# Altium schematic sheet 05-AT86RF215IQ-FEM.SchDoc
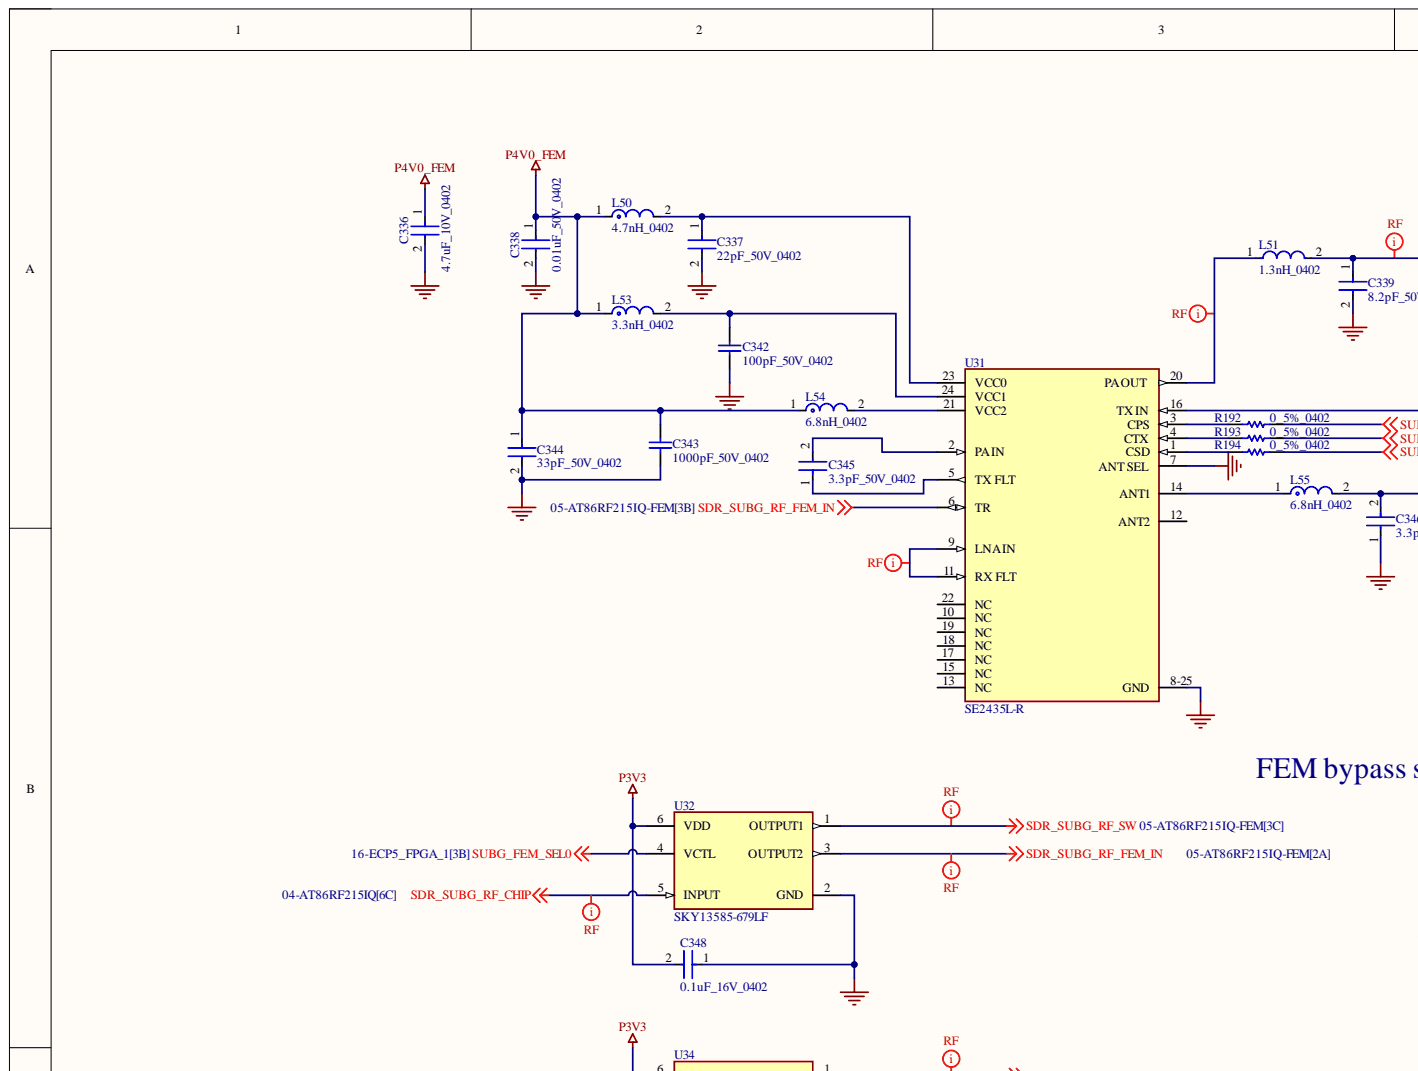
[diagram p0: 05-AT86RF215IQ-FEM.SchDoc, top left]
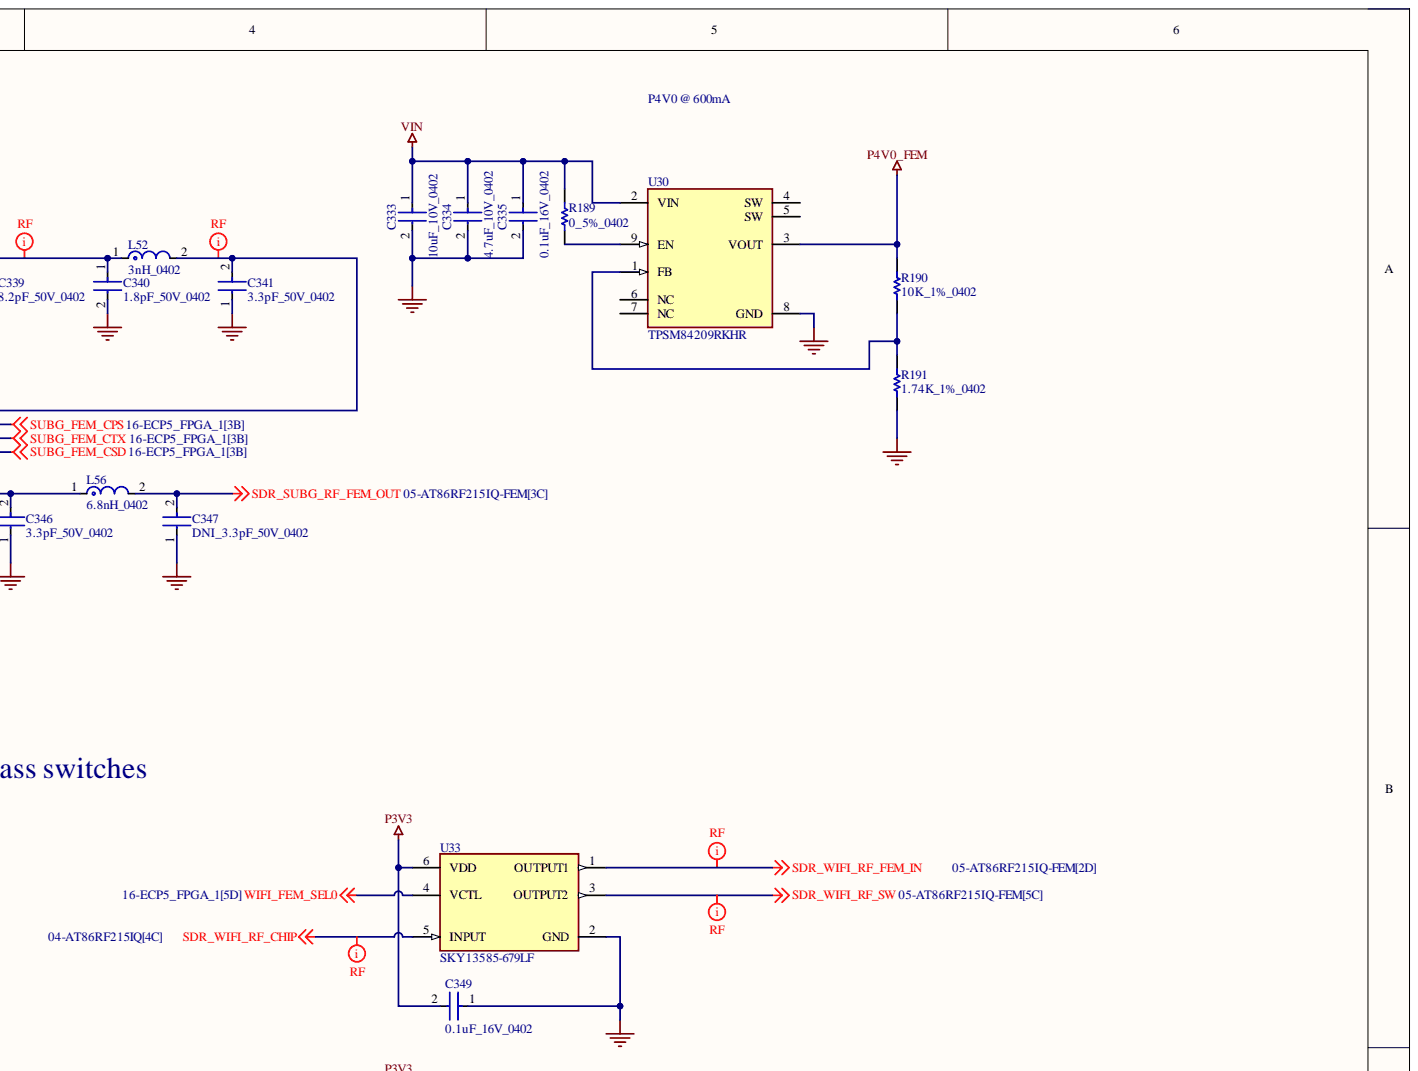
[diagram p1: 05-AT86RF215IQ-FEM.SchDoc, top right]
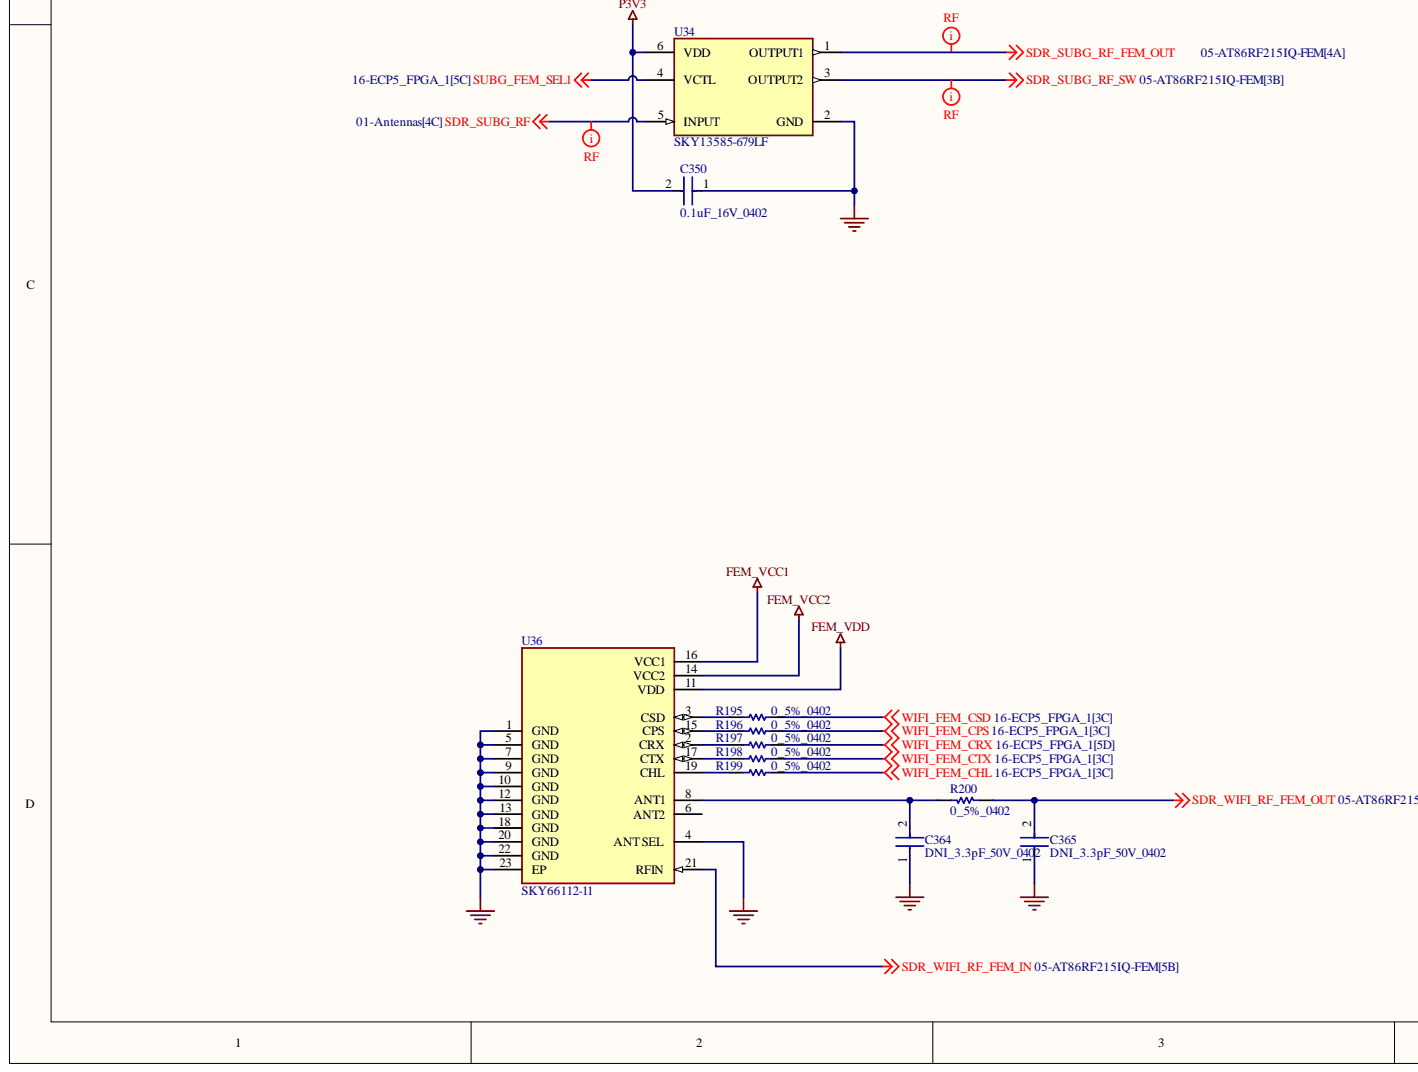
[diagram p2: 05-AT86RF215IQ-FEM.SchDoc, bottom left]
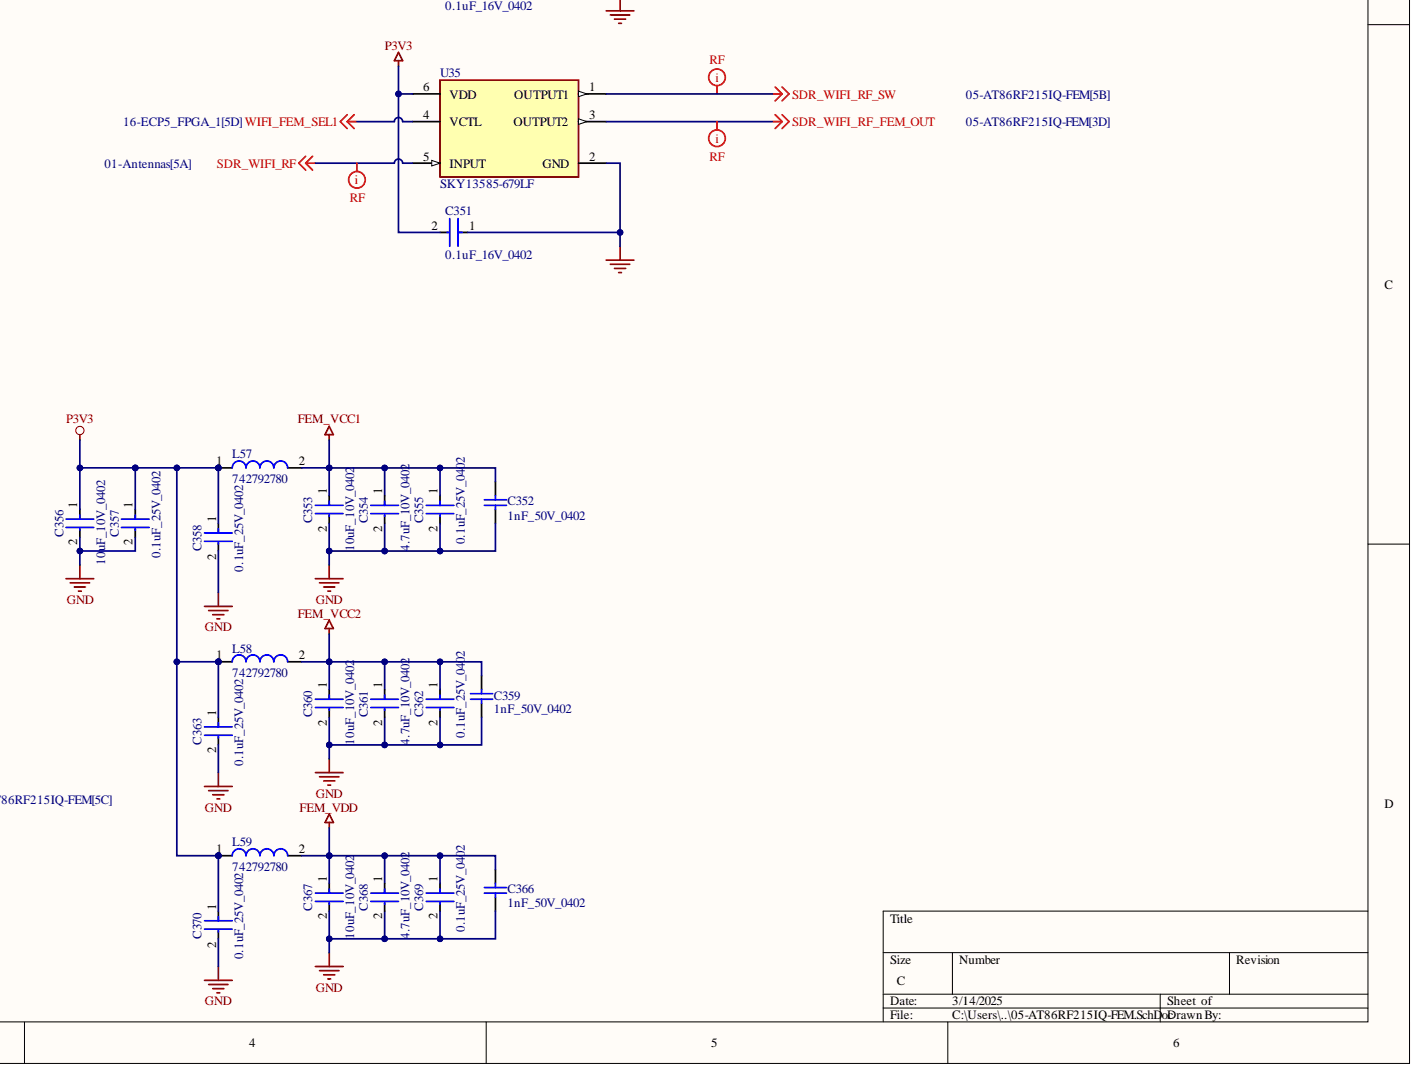
[diagram p3: 05-AT86RF215IQ-FEM.SchDoc, bottom right]

## Parts
C333  10uF_10V_0402  [FP-CL05A106MP5NUNC-MFG]
C334  4.7uF_10V_0402  [FP-C1005-050-0_15-MFG]
C335  0.1uF_16V_0402  [FP-C0402-BB-MFG]
C336  4.7uF_10V_0402  [FP-C1005-050-0_15-MFG]
C337  22pF_50V_0402  [FP-0402-L_1_0_1-W_0_5_0_1-MFG]
C338  0.01uF_50V_0402  [FP-GCM155-0_05-IPC_C]
C339  8.2pF_50V_0402  [FP-GJM155-0_05-IPC_C]
C340  1.8pF_50V_0402  [FP-GJM155-0_05-IPC_B]
C341  3.3pF_50V_0402  [FP-CBR04C-MFG]
C342  100pF_50V_0402  [CAPC1005X55X25ML05T10]
C343  1000pF_50V_0402  [CAPC1005X55X25ML5T10]
C344  33pF_50V_0402  [FP-0402-L_1_0_1-W_0_5_0_1-IPC_A]
C345  3.3pF_50V_0402  [FP-GCM155-0_05-IPC_B]
C346  3.3pF_50V_0402  [FP-CBR04C-MFG]
C347  DNI_3.3pF_50V_0402  [FP-CBR04C-MFG]  (no BOM)
C348  0.1uF_16V_0402  [FP-C0402-BB-MFG]
C349  0.1uF_16V_0402  [FP-C0402-BB-MFG]
C350  0.1uF_16V_0402  [FP-C0402-BB-MFG]
C351  0.1uF_16V_0402  [FP-C0402-BB-MFG]
C352  1nF_50V_0402  [CAPC1005X55X25ML5T10]
C353  10uF_10V_0402  [FP-CL05A106MP5NUNC-MFG]
C354  4.7uF_10V_0402  [FP-C1005-050-0_15-MFG]
C355  0.1uF_25V_0402  [FP-0402-L_1_0_1-W_0_5_0_1-IPC_C]
C356  10uF_10V_0402  [FP-CL05A106MP5NUNC-MFG]
C357  0.1uF_25V_0402  [FP-0402-L_1_0_1-W_0_5_0_1-IPC_C]
C358  0.1uF_25V_0402  [FP-0402-L_1_0_1-W_0_5_0_1-IPC_C]
C359  1nF_50V_0402  [CAPC1005X55X25ML5T10]
C360  10uF_10V_0402  [FP-CL05A106MP5NUNC-MFG]
C361  4.7uF_10V_0402  [FP-C1005-050-0_15-MFG]
C362  0.1uF_25V_0402  [FP-0402-L_1_0_1-W_0_5_0_1-IPC_C]
C363  0.1uF_25V_0402  [FP-0402-L_1_0_1-W_0_5_0_1-IPC_C]
C364  DNI_3.3pF_50V_0402  [FP-CBR04C-MFG]  (no BOM)
C365  DNI_3.3pF_50V_0402  [FP-CBR04C-MFG]  (no BOM)
C366  1nF_50V_0402  [CAPC1005X55X25ML5T10]
C367  10uF_10V_0402  [FP-CL05A106MP5NUNC-MFG]
C368  4.7uF_10V_0402  [FP-C1005-050-0_15-MFG]
C369  0.1uF_25V_0402  [FP-0402-L_1_0_1-W_0_5_0_1-IPC_C]
C370  0.1uF_25V_0402  [FP-0402-L_1_0_1-W_0_5_0_1-IPC_C]
L50  4.7nH_0402  [FP-LQG15HH_02-IPC_B]
L51  1.3nH_0402  [FP-LQW15AN_00-W0_6-0_1-MFG]
L52  3nH_0402  [FP-LQG15HH_02-IPC_A]
L53  3.3nH_0402  [FP-LQG15HH_02-IPC_B]
L54  6.8nH_0402  [FP-LQG15HH_02-IPC_A]
L55  6.8nH_0402  [FP-LQG15HH_02-IPC_A]
L56  6.8nH_0402  [FP-LQG15HH_02-IPC_A]
L57  742792780  [SMD-0402]
L58  742792780  [SMD-0402]
L59  742792780  [SMD-0402]
R189  0_5%_0402  [RESC1005X40X25NL05T10]
R190  10K_1%_0402  [RESC1005X40X25LL05T10]
R191  1.74K_1%_0402  [RESC1005X40X25NL05T05]
R192  0_5%_0402  [RESC1005X40X25NL05T10]
R193  0_5%_0402  [RESC1005X40X25NL05T10]
R194  0_5%_0402  [RESC1005X40X25NL05T10]
R195  0_5%_0402  [RESC1005X40X25NL05T10]
R196  0_5%_0402  [RESC1005X40X25NL05T10]
R197  0_5%_0402  [RESC1005X40X25NL05T10]
R198  0_5%_0402  [RESC1005X40X25NL05T10]
R199  0_5%_0402  [RESC1005X40X25NL05T10]
R200  0_5%_0402  [RESC1005X40X25NL05T10]
U30  TPSM84209RKHR  [FP-RKH0009A-MFG]
U31  SE2435L-R  [FP-SE2435L-R-MFG]
U32  SKY13585-679LF  [FP-SKY13585-679LF-MFG]
U33  SKY13585-679LF  [FP-SKY13585-679LF-MFG]
U34  SKY13585-679LF  [FP-SKY13585-679LF-MFG]
U35  SKY13585-679LF  [FP-SKY13585-679LF-MFG]
U36  SKY66112-11  [FP-SKY66112-11-MFG]

## Nets
FEM_VCC1: C352.2 C353.1 C354.1 C355.1 L57.2 U36.16(VCC1)
FEM_VCC2: C359.2 C360.1 C361.1 C362.1 L58.2 U36.14(VCC2)
FEM_VDD: C366.2 C367.1 C368.1 C369.1 L59.2 U36.11(VDD)
GND: C333.2 C334.2 C335.2 C336.2 C337.2 C338.2 C339.2 C340.2 C341.1 C342.1 C343.1 C344.2 C346.1 C347.1 C348.1 C349.1 C350.1 C351.1 C352.1 C353.2 C354.2 C355.2 C356.2 C357.2 C358.2 C359.1 C360.2 C361.2 C362.2 C363.2 C364.1 C365.1 C366.1 C367.2 C368.2 C369.2 C370.2 R191.1 U30.8(GND) U31.7(ANT_SEL) U31.8-25(GND) U32.2(GND) U33.2(GND) U34.2(GND) U35.2(GND) U36.1(GND) U36.4(ANT_SEL) U36.5(GND) U36.7(GND) U36.9(GND) U36.10(GND) U36.12(GND) U36.13(GND) U36.18(GND) U36.20(GND) U36.22(GND) U36.23(EP)
P3V3: C348.2 C349.2 C350.2 C351.2 C356.1 C357.1 C358.1 C363.1 C370.1 L57.1 L58.1 L59.1 U32.6(VDD) U33.6(VDD) U34.6(VDD) U35.6(VDD)
P4V0_FEM: C336.1 C338.1 C343.2 C344.1 L50.1 L53.1 L54.1 R190.2 U30.3(VOUT)
SDR_SUBG_RF: U34.5(INPUT)
SDR_SUBG_RF_CHIP: U32.5(INPUT)
SDR_SUBG_RF_FEM_IN: U31.6(TR) U32.3(OUTPUT2)
SDR_SUBG_RF_FEM_OUT: C347.2 L56.2 U34.1(OUTPUT1)
SDR_SUBG_RF_SW: U32.1(OUTPUT1) U34.3(OUTPUT2)
SDR_WIFI_RF: U35.5(INPUT)
SDR_WIFI_RF_CHIP: U33.5(INPUT)
SDR_WIFI_RF_FEM_IN: U33.1(OUTPUT1) U36.21(RFIN)
SDR_WIFI_RF_FEM_OUT: C365.2 R200.1 U35.3(OUTPUT2)
SDR_WIFI_RF_SW: U33.3(OUTPUT2) U35.1(OUTPUT1)
SUBG_FEM_CPS: R192.2
SUBG_FEM_CSD: R194.2
SUBG_FEM_CTX: R193.2
SUBG_FEM_SEL0: U32.4(VCTL)
SUBG_FEM_SEL1: U34.4(VCTL)
VIN: C333.1 C334.1 C335.1 R189.2 U30.2(VIN)
WIFI_FEM_CHL: R199.2
WIFI_FEM_CPS: R196.2
WIFI_FEM_CRX: R197.2
WIFI_FEM_CSD: R195.2
WIFI_FEM_CTX: R198.2
WIFI_FEM_SEL0: U33.4(VCTL)
WIFI_FEM_SEL1: U35.4(VCTL)
NetC337_1: C337.1 L50.2 U31.23(VCC0)
NetC339_1: C339.1 C340.1 L51.2 L52.1
NetC341_2: C341.2 L52.2 U31.16(TX_IN)
NetC342_2: C342.2 L53.2 U31.24(VCC1)
NetC345_1: C345.1 U31.5(TX_FLT)
NetC345_2: C345.2 U31.2(PA_IN)
NetC346_2: C346.2 L55.2 L56.1
NetC364_2: C364.2 R200.2 U36.8(ANT1)
NetL51_1: L51.1 U31.20(PA_OUT)
NetL54_2: L54.2 U31.21(VCC2)
NetL55_1: L55.1 U31.14(ANT1)
NetR189_1: R189.1 U30.9(EN)
NetR190_1: R190.1 R191.2 U30.1(FB)
NetR192_1: R192.1 U31.3(CPS)
NetR193_1: R193.1 U31.4(CTX)
NetR194_1: R194.1 U31.1(CSD)
NetR195_1: R195.1 U36.3(CSD)
NetR196_1: R196.1 U36.15(CPS)
NetR197_1: R197.1 U36.2(CRX)
NetR198_1: R198.1 U36.17(CTX)
NetR199_1: R199.1 U36.19(CHL)
NetU31_9: U31.9(LNA_IN) U31.11(RX_FLT)

Not connected: U30 4(SW) 5(SW) 6(NC) 7(NC); U31 10(NC) 12(ANT2) 13(NC) 15(NC) 17(NC) 18(NC) 19(NC) 22(NC); U36 6(ANT2)

## Library data of the parts
C333 C353 C356 C360 C367 = CMP-13271-000002-1: CAP CER 10UF 10V X5R 0402; Manufacturer: Samsung; Manufacturer Part Number: CL05A106MP5NUNC; Material: Ceramic; Temperature Coefficient: 15%; Tolerance: 20%; Automotive: No; Capacitance: 10uF; Category: Cap; Component Kind: Standard; ComponentLink2Description: Datasheet; ComponentLink2URL: http://www.samsungsem.com/kr/support/product-search/mlcc/__icsFiles/afieldfile/2019/05/10/19-CL05A106MP5NUNC.pdf; Device Class L1: Passive Components; Device Class L2: Capacitors; Device Class L3: Ceramic Capacitors; Digikey Description: CAP CER 10UF 10V X5R 0402; Height: 0.7mm; Ipc Land Pattern Name: CAPC10050X50; Lead Free: Yes; Library Name: Altium Content Vault; Octopart: https://octopart.com/cl05a106mp5nunc-samsung-22457395; Package: CL05A106MP5NUNC; RoHS: TRUE; Temperature: -55°C to +85°C; Temperature Characteristic: X5R; Temperature Range High: +85°C; Temperature Range Low: -55°C; Value: 10uF; Voltage Rating: 10V
C334 C336 C354 C361 C368 = CMP-2000-05997-2: CAP CER 4.7UF 10V X5R 0402; Dielectric Material: Ceramic; Tolerance: 10%; Capacitance: 4.7uF; Case Code (Imperial): 0402; Case Code (Metric): 1005; Case/Package: 0402; Component Kind: Standard; Dielectric: X5R; Lead Free: Lead Free; Length: 0.039inch; Library Name: Altium Content Vault; Max Operating Temperature: 85°C; Min Operating Temperature: -55°C; Mount: Surface Mount; Package Quantity: 10000; Packaging: Cut Tape; REACH SVHC: No SVHC; RoHS Compliant: Yes; Series: C; Thickness: 0.022inch; Voltage Rating: 10V; Voltage Rating (DC): 10V; Width: 0.02inch
C335 C348-C351 = CMP-1034-02068-3: CAP CER 0.1UF 16V 5% X7R 0402; Manufacturer: Kemet; Manufacturer Part Number: C0402C104J4RACTU; Capacitance: 0.10 μF; Material: Ceramic; Temperature Coefficient: 15%; Tolerance: 0.05; Value: 0.10 μF; Automotive: No; Category: Cap; Component Kind: Standard; ComponentLink2Description: Datasheet; ComponentLink2URL: https://content.kemet.com/datasheets/KEM_C1002_X7R_SMD.pdf; Device Class L1: Passive Components; Device Class L2: Capacitors; Device Class L3: Ceramic Capacitors; Digikey Description: CAP CER 0.1UF 16V 5% X7R 0402; Height: 0.55mm; Ipc Land Pattern Name: CAPC10050X50; Lead Free: Yes; Library Name: Altium Content Vault; Mouser Description: CAP CER 0.1UF 16V X7R 0402; Octopart: https://octopart.com/c0402c104j4ractu-kemet-12573893; Package: C0402-BB; RoHS: TRUE; Temperature: -55°C to +125°C; Temperature Characteristic: X7R; Temperature Range High: +125°C; Temperature Range Low: -55°C; Voltage Rating: 16 V
C337 = CMP-2008-02613-2: General Purpose Ceramic Capacitor, 0402, 22pF, 5%, C0G, 30ppm/°C, 50V; Capacitance: 22pF; Case Code (Imperial): 0402; Case Code (Metric): 1005; Case/Package: 0402; Component Kind: Standard; Construction: Flat; Contact Plating: Tin; Depth: 0.5mm; Dielectric: C0G; Height: 0.5mm; Lead Free: Lead Free; Length: 1mm; Library Name: Altium Content Vault; Max Operating Temperature: 125°C; Min Operating Temperature: -55°C; Mount: Surface Mount; Number of Pins: 2; Package Quantity: 1; Packaging: Tape and Reel; Radiation Hardening: No; REACH SVHC: No SVHC; RoHS Compliant: Yes; Series: -; Temperature Coefficient: 30ppm/°C; Termination: SMD/SMT; Thickness: 0.022inch; Tolerance: 5%; Voltage: 50V; Voltage Rating: 50V; Voltage Rating (DC): 50V; Width: 0.02inch
C338 = CMP-2008-03723-3: Ceramic Capacitor for Automotive 10nF ±10% 50VDC X7R 0402 Paper T/R; Voltage: 50V; Capacitance: 10nF; Case Code (Imperial): 0402; Case Code (Metric): 1005; Case/Package: 0402; Component Kind: Standard; Depth: 0.5mm; Dielectric: X7R; Height: 0.5mm; Lead Free: Lead Free; Length: 1mm; Library Name: Altium Content Vault; Max Operating Temperature: 125°C; Min Operating Temperature: -55°C; Mount: Surface Mount; Package Quantity: 1; Packaging: Tape and Reel; Radiation Hardening: No; Ratings: AEC-Q200; REACH SVHC: No SVHC; Termination: SMD/SMT; Thickness: 0.022inch; Tolerance: 10%; Voltage Rating: 50V; Voltage Rating (DC): 50V; Width: 0.02inch
C339 = CMP-2008-03886-2: Multi-Layer Ceramic Capacitor 8.2pF C0G  ±0.25pF 0402 Paper T/R; Temperature Coefficient: 30ppm/°C; Voltage: 50V; Capacitance: 8.2pF; Case Code (Imperial): 0402; Case Code (Metric): 1005; Case/Package: 0402; Component Kind: Standard; Depth: 0.5mm; Dielectric: C0G; Height: 0.5mm; Lead Free: Lead Free; Lead Pitch: 0.3mm; Length: 1mm; Library Name: Altium Content Vault; Max Operating Temperature: 125°C; Min Operating Temperature: -55°C; Mount: Surface Mount; Number of Pins: 2; Package Quantity: 1; Packaging: Tape and Reel; Radiation Hardening: No; REACH SVHC: No SVHC; Termination: SMD/SMT; Thickness: 0.022inch; Voltage Rating: 50V; Voltage Rating (DC): 50V; Width: 0.5mm
C340 = CMP-2008-03825-2: Multi-Layer Ceramic Capacitor 1.8pF C0G  ±0.1pF 0402 Paper T/R; Voltage: 50V; Capacitance: 1.8pF; Case Code (Imperial): 0402; Case Code (Metric): 1005; Case/Package: 0402; Component Kind: Standard; Depth: 0.5mm; Dielectric: C0G; Height: 0.5mm; Lead Free: Lead Free; Lead Pitch: 0.3mm; Length: 1mm; Library Name: Altium Content Vault; Max Operating Temperature: 125°C; Min Operating Temperature: -55°C; Mount: Surface Mount; Number of Pins: 2; Package Quantity: 10000; Packaging: Tape and Reel; Radiation Hardening: No; REACH SVHC: No SVHC; Termination: SMD/SMT; Thickness: 0.022inch; Voltage Rating: 50V; Voltage Rating (DC): 50V; Width: 0.5mm
C341 C346 C347 C364 C365 = CMP-2008-00014-2: CAP CER 3.3PF 50V C0G/NP0 0402; Manufacturer: KEMET; Manufacturer Part Number: CBR04C339C5GAC; Material: Ceramic; Temperature Characteristic: C0G; Temperature Coefficient: 30ppm/°C; Tolerance: 0.25 pF; Automotive: No; Capacitance: 3.3 pF; Category: Cap; ComponentLink2Description: Datasheet; ComponentLink2URL: https://content.kemet.com/datasheets/KEM_C1030_CBR_SMD.pdf; Device Class L1: Passive Components; Device Class L2: Capacitors; Device Class L3: Ceramic Capacitors; Digikey Description: CAP CER 3.3PF 50V C0G/NP0 0402; Height: 0.55mm; Ipc Land Pattern Name: CAPC10050X50; Lead Free: Yes; Library Name: Altium Content Vault; Mouser Description: Cap Ceramic 3.3pF 50V C0G 0.25pF SMD 0402 125°C Paper T/R; Octopart: https://octopart.com/cbr04c339c5gac-kemet-20446747; Package: CBR04C; RoHS: TRUE; Temperature: -55°C to +125°C; Temperature Range High: +125°C; Temperature Range Low: -55°C; Value: 3.3 pF; Voltage Rating: 50 V
  C341 C346: Component Kind: Standard
C342 = CMP-2008-03915-1: Manufacturer: Murata; Part Number: GRM1555C1H101JA01D; Component Kind: Standard; Library Name: Altium Content Vault
C343 C352 C359 C366 = CMP-1662-00017-1: Chip Capacitor, 1 nF, +/- 10%, 50 V, -55 to 125 degC, 0402 (1005 Metric), RoHS, Tape and Reel; Capacitance: 1 nF; Case\/Package: 0402; Type (Capacitors): X7R; Voltage Rating: 50 V; Case Code (Imperial): 0402; Case Code (Metric): 1005; Component Kind: Standard; ComponentLink1Description: Manufacturer URL; ComponentLink1URL: http://www.murata.com/; ComponentLink2Description: Datasheet; ComponentLink2URL: http://psearch.en.murata.com/capacitor/product/GRM155R71H102KA01%23.pdf; DatasheetVersion: 07/2016; Height: 0.5 mm; Length: 1 mm; Library Name: Altium Content Vault; Max Operating Temperature: 125 degC; Min Operating Temperature: -55 degC; Mount: Surface Mount; Mounting Technology: Surface Mount; Package Quantity: 10000; PackageDescription: 2-Pin Surface Mount Device, Body 1 x 0.5 mm; PackageReference: 0402; Packaging: Tape and Reel; Pins: 2; Radiation Hardening: No; REACH SVHC: No SVHC; RoHSCompliant: true; Tolerance: 10%; Voltage Rating (DC): 50 V; Width: 0.5 mm
C344 = CMP-2008-02637-2: General Purpose Ceramic Capacitor, 0402, 33pF, 5%, C0G, 30ppm/°C, 50V; Insulation Resistance: 100GR; Capacitance: 33pF; Case Code (Imperial): 0402; Case Code (Metric): 1005; Case/Package: 0402; Component Kind: Standard; Construction: Flat; Contact Plating: Tin; Depth: 0.5mm; Dielectric: C0G; Height: 0.5mm; Lead Free: Lead Free; Length: 1mm; Library Name: Altium Content Vault; Max Operating Temperature: 125°C; Min Operating Temperature: -55°C; Mount: Surface Mount; Number of Pins: 2; Package Quantity: 1; Packaging: Tape and Reel; Radiation Hardening: No; REACH SVHC: No SVHC; RoHS Compliant: Yes; Series: -; Temperature Coefficient: 30ppm/°C; Termination: SMD/SMT; Thickness: 0.022inch; Tolerance: 5%; Voltage: 50V; Voltage Rating: 50V; Voltage Rating (DC): 50V; Width: 0.5mm
C345 = CMP-06035-045561-1: Ceramic Capacitor for Automotive 3.3pF ±0.25pF 50VDC C0G 0402 Paper T/R; Voltage Rating: 50V; Capacitance: 3.3pF; Case Code (Imperial): 0402; Case Code (Metric): 1005; Case/Package: 0402; Component Kind: Standard; Dielectric: C0G; Height: 0.5mm; Length: 0.039inch; Library Name: Altium Content Vault; Max Operating Temperature: 125°C; Min Operating Temperature: -55°C; Mount: Surface Mount; Package Quantity: 10000; Packaging: Tape and Reel; Ratings: AEC-Q200; Termination: SMD/SMT; Thickness: 0.022inch; Voltage Rating (DC): 50V; Weight: 0.000212oz; Width: 0.02inch
C355 C357 C358 C362 C363 C369 C370 = CMP-2008-02519-2: General Purpose Ceramic Capacitor, 0402, 100nF, 10%, X7R, 15%, 25V; Capacitance: 100nF; Case Code (Imperial): 0402; Case Code (Metric): 1005; Case/Package: 0402; Component Kind: Standard; Construction: Flat; Depth: 0.5mm; Dielectric: X7R; Lead Free: Lead Free; Length: 1mm; Library Name: Altium Content Vault; Max Operating Temperature: 125°C; Min Operating Temperature: -55°C; Mount: Surface Mount; Number of Pins: 2; Package Quantity: 1; Packaging: Tape and Reel; Radiation Hardening: No; REACH SVHC: No SVHC; RoHS Compliant: Yes; Series: -; Termination: SMD/SMT; Thickness: 0.022inch; Tolerance: 10%; Voltage: 25V; Voltage Rating: 25V; Voltage Rating (DC): 25V; Width: 0.02inch
L50 = CMP-06042-003214-1: Multilayer type RF Inductor 4.7nH ±0.2nH 0.16Ω 700mA 0402; Core Material: Air; DC Resistance (DCR): 160mR; Self Resonant Frequency: 6GHz; Shielding: Unshielded; Test Frequency: 100MHz; Case Code (Imperial): 0402; Case Code (Metric): 1005; Case/Package: 0402; Component Kind: Standard; Current Rating: 700mA; Inductance: 4.7nH; Library Name: Altium Content Vault; Max Operating Temperature: 125°C; Min Operating Temperature: -55°C; Mount: Surface Mount; Packaging: Cut Tape
L51 = CMP-06042-000011-2: Wire Wound RF Inductor 1.3nH ±0.2nH 1200mA 0.017Ω 0402 (1005); Core Material: Air; Current Rating: 1.2A; DC Resistance (DCR): 17mR; Self Resonant Frequency: 16GHz; Shielding: Unshielded; Test Frequency: 100MHz; Case Code (Imperial): 0402; Case Code (Metric): 1005; Case/Package: 0402; Component Kind: Standard; DC Current: 1.2A; Depth: 0.7mm; Height: 0.5mm; Inductance: 1.3nH; Lead Free: Lead Free; Length: 1mm; Library Name: Altium Content Vault; Max DC Current: 1.2A; Max Operating Temperature: 125°C; Military Standard: Not; Min Operating Temperature: -55°C; Mount: Surface Mount; Number of Pins: 2; Package Quantity: 10000; Packaging: Tape and Reel; Radiation Hardening: No; REACH SVHC: No SVHC; Termination: SMD/SMT; Weight: 2.8E-05oz; Width: 0.02inch
L52 = CMP-06042-002412-1: Multilayer type RF Inductor 3nH ±0.3nH 0.125Ω 800mA 0402; Core Material: Air; Current Rating: 300mA; DC Resistance (DCR): 170mR; Q Factor: 8; Self Resonant Frequency: 6GHz; Shielding: Unshielded; Test Frequency: 100MHz; Case Code (Imperial): 0402; Case Code (Metric): 1005; Case/Package: 0402; Component Kind: Standard; DC Current: 300mA; Depth: 0.55mm; Height: 0.5mm; Height - Seated (Max): 0.022inch; Inductance: 3nH; Lead Free: Lead Free; Length: 1mm; Library Name: Altium Content Vault; Max DC Current: 300mA; Max Operating Temperature: 125°C; Military Standard: Not; Min Operating Temperature: -55°C; Mount: Surface Mount; Package Quantity: 10000; Packaging: Tape and Reel; Radiation Hardening: No; Termination: SMD/SMT; Width: 0.02inch
L53 = CMP-06042-000228-1: Multilayer type RF Inductor 3.3nH ±0.3nH 0.125Ω 800mA 0402; Core Material: Air; Current Rating: 300mA; DC Resistance (DCR): 170mR; Q Factor: 8; Self Resonant Frequency: 6GHz; Shielding: Unshielded; Test Frequency: 100MHz; Case Code (Imperial): 0402; Case Code (Metric): 1005; Case/Package: 0402; Component Kind: Standard; DC Current: 300mA; Depth: 0.55mm; Height: 0.5mm; Height - Seated (Max): 0.022inch; Inductance: 3.3nH; Lead Free: Lead Free; Length: 1mm; Library Name: Altium Content Vault; Max DC Current: 300mA; Max Operating Temperature: 125°C; Military Standard: Not; Min Operating Temperature: -55°C; Mount: Surface Mount; Package Quantity: 1; Packaging: Tape and Reel; Radiation Hardening: No; Termination: SMD/SMT; Width: 0.02inch
L54-L56 = CMP-06042-003922-1: Multilayer type RF Inductor 6.8nH ±5% 0.22Ω 600mA 0402; Core Material: Air; Current Rating: 300mA; DC Resistance (DCR): 240mR; Q Factor: 8; Self Resonant Frequency: 4.5GHz; Shielding: Unshielded; Test Frequency: 100MHz; Case Code (Imperial): 0402; Case Code (Metric): 1005; Case/Package: 0402; Component Kind: Standard; DC Current: 300mA; Depth: 0.55mm; Height: 0.5mm; Height - Seated (Max): 0.022inch; Inductance: 6.8nH; Lead Free: Lead Free; Length: 1mm; Library Name: Altium Content Vault; Max DC Current: 300mA; Max Operating Temperature: 125°C; Military Standard: Not; Min Operating Temperature: -55°C; Mount: Surface Mount; Package Quantity: 10000; Packaging: Tape and Reel; Radiation Hardening: No; Termination: SMD/SMT; Tolerance: 5%; Width: 0.02inch
L57-L59 = CMP-0220-00137-1: SMD EMI Suppression Ferrite Bead WE-CBF, Z = 220 Ohm; Impedance: 220 Ohm; Component Kind: Standard; ComponentLink1Description: Manufacturer Link; ComponentLink1URL: http://www.we-online.com; ComponentLink2Description: Datasheet; ComponentLink2URL: http://www.we-online.de/katalog/media/pdf/742792780.pdf; DatasheetVersion: ver 4, Jul-2009; Library Name: Altium Content Vault; PackageDescription: Chip Inductor, 2-Leads, Body 1.0 x 0.5 mm; PackageReference: SMD-0402; PackageVersion: 2009
R189 R192-R200 = CMP-2002-06457-1: Manufacturer: Panasonic; Part Number: ERJ-U020R00X; Component Kind: Standard; Library Name: Altium Content Vault
R190 = CMP-2002-07353-1: Manufacturer: Yageo / Phycomp; Part Number: RC0402FR-0710KL; Component Kind: Standard; Library Name: Altium Content Vault
R191 = CMP-2002-00727-1: Manufacturer: Panasonic; Part Number: ERJ-2RKF1741X; Component Kind: Standard; Library Name: Altium Content Vault
U30 = CMP-04918-000417-1: DC DC CONVERTER 1.2-6V; Component Kind: Standard; Library Name: Altium Content Vault
U31 = CMP-85923-000004-1: IC FRONT END 930MHZ ISM 24QFN; Operating Frequency: 860 MHz to 930 MHz; Operating Supply Current: 50mA; Operating Supply Voltage: 3V; Case/Package: QFN; Component Kind: Standard; Frequency: 930MHz; Library Name: Altium Content Vault; Max Operating Temperature: 85°C; Min Operating Temperature: -40°C; Package Quantity: 1; Packaging: Tape and Reel; Series: SiGe; Weight: 0.032741oz
U32-U35 = CMP-85923-000009-1: IC RF SWITCH SPDT 6GHZ 6MLPD; IIP3: 56dBm; Impedance: 50R; Insertion Loss: 0.5dB; Test Frequency: 2.5GHz; Component Kind: Standard; Library Name: Altium Content Vault; Max Operating Temperature: 90°C; Min Operating Temperature: -40°C; Packaging: Cut Tape; RoHS Compliant: Yes; Series: -; Throw Configuration: SPDT
U36 = CMP-85923-000012-1: CONNECTED HOME/WEARABLEBLE+ZIGBE; Frequency: 2.4GHz; Case/Package: SMD/SMT; Component Kind: Standard; Library Name: Altium Content Vault; Packaging: Cut Tape; RoHS Compliant: Yes; Series: -
